# Altium schematic sheet CUBE_WIRELESS.SchDoc
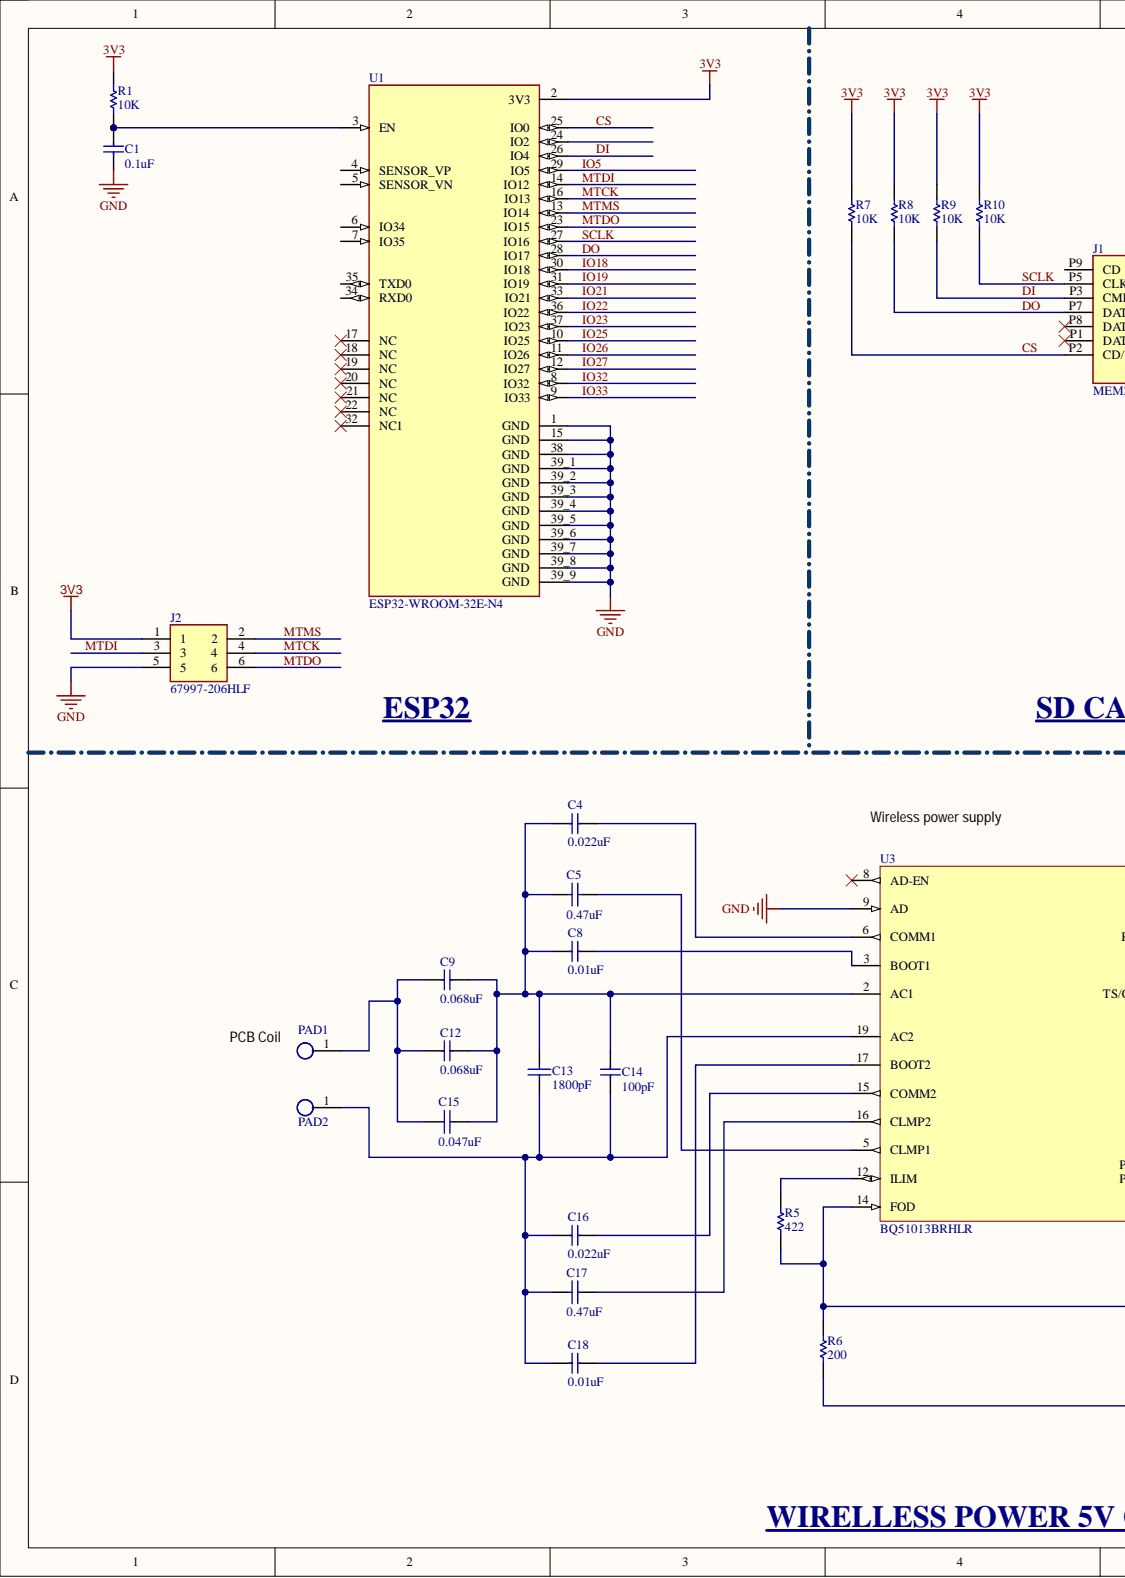
[diagram p0: CUBE_WIRELESS.SchDoc, left]
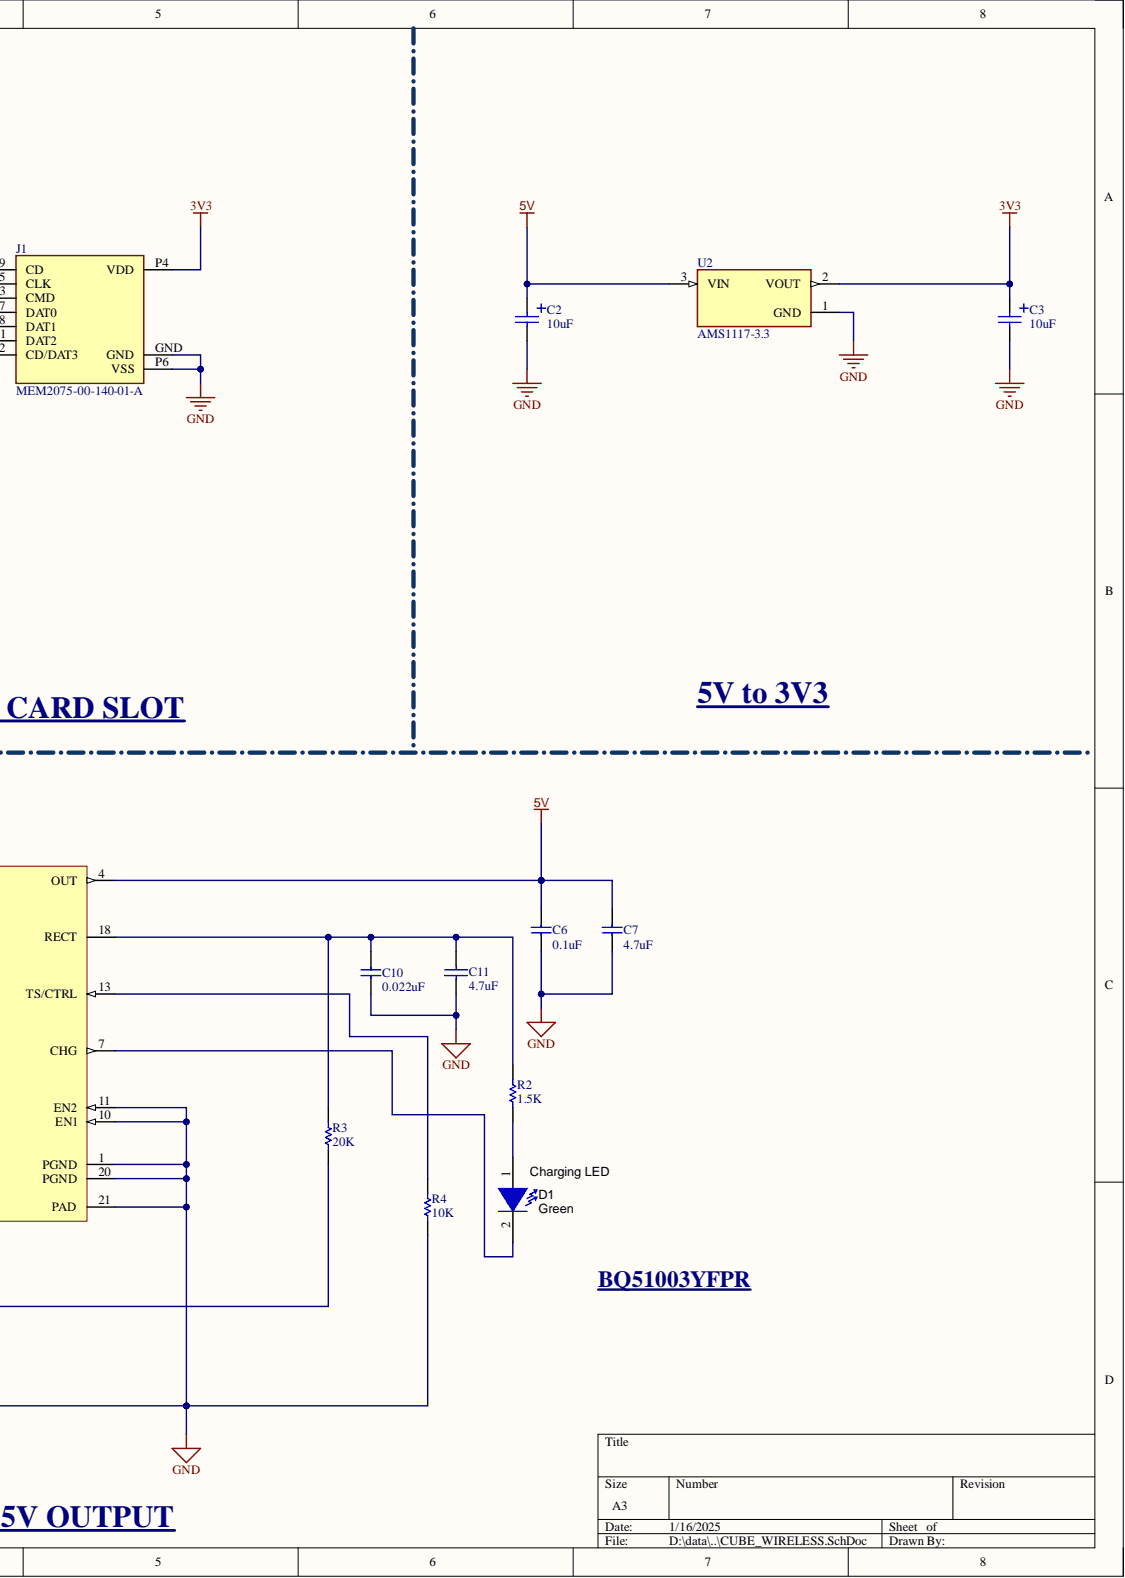
[diagram p1: CUBE_WIRELESS.SchDoc, right]

## Parts
C1  0.1uF  [CAPC1005X04L]
C2  10uF  [C0805+]
C3  10uF  [C0805+]
C4  0.022uF  [CAPC1005X04L]
C5  0.47uF  [C0603]
C6  0.1uF  [CAPC1005X04L]
C7  4.7uF  [CAPC1005X04L]
C8  0.01uF  [CAPC1005X04L]
C9  0.068uF  [CAPC1005X04L]
C10  0.022uF  [CAPC1005X04L]
C11  4.7uF  [C0603]
C12  0.068uF  [CAPC1005X04L]
C13  1800pF  [C0603]
C14  100pF  [CAPC1005X04L]
C15  0.047uF  [C0603]
C16  0.022uF  [CAPC1005X04L]
C17  0.47uF  [C0603]
C18  0.01uF  [CAPC1005X04L]
D1  value Green  [LED_LTST-C190]  (hidden comment: LTST-C190GKT)
J1  MEM2075-00-140-01-A  [MEM20750014001A]
J2  67997-206HLF  [67997-206HLF]
PAD1  PAD  [test_point]
PAD2  PAD  [test_point]
R1  10K  [R0402]
R2  1.5K  [R0402]
R3  20K  [R0402]
R4  10K  [R0402]
R5  422  [R0402]
R6  200  [R0402]
R7  10K  [R0402]
R8  10K  [R0402]
R9  10K  [R0402]
R10  10K  [R0402]
U1  ESP32-WROOM-32E-N4  [XCVR_ESP32-WROOM-32E_(16MB)]
U2  AMS1117-3.3  [SOT229P700X180-4N]
U3  BQ51013BRHLR  [RHL0020A]

## Nets
3V3: C3.1 J1.P4(VDD) J2.1 R1.2 R7.2 R8.2 R9.2 R10.2 U1.2(3V3) U2.2
5V: C2.1 C6.2 C7.2 U2.3 U3.4(OUT)
GND: C1.1 C2.2 C3.2 C6.1 C7.1 C10.1 C11.1 J1.GND J1.P6(VSS) J2.5 R4.1 R6.1 U1.1(GND) U1.15(GND) U1.38(GND) U1.39_1(GND) U1.39_2(GND) U1.39_3(GND) U1.39_4(GND) U1.39_5(GND) U1.39_6(GND) U1.39_7(GND) U1.39_8(GND) U1.39_9(GND) U2.1 U3.1(PGND) U3.9(AD) U3.10(EN1) U3.11(EN2) U3.20(PGND) U3.21(PAD)
CS: J1.P2(CD/DAT3) R7.1 U1.25(IO0)
DI: J1.P3(CMD) R9.1 U1.26(IO4)
DO: J1.P7(DAT0) R8.1 U1.28(IO17)
IO5: U1.29(IO5)
IO18: U1.30(IO18)
IO19: U1.31(IO19)
IO21: U1.33(IO21)
IO22: U1.36(IO22)
IO23: U1.37(IO23)
IO25: U1.10(IO25)
IO26: U1.11(IO26)
IO27: U1.12(IO27)
IO32: U1.8(IO32)
IO33: U1.9(IO33)
MTCK: J2.4 U1.16(IO13)
MTDI: J2.3 U1.14(IO12)
MTDO: J2.6 U1.23(IO15)
MTMS: J2.2 U1.13(IO14)
SCLK: J1.P5(CLK) R10.1 U1.27(IO16)
NetC1_2: C1.2 R1.1 U1.3(EN)
NetC4_1: C4.1 C5.1 C8.1 C9.2 C12.2 C13.2 C14.2 C15.2 U3.2(AC1)
NetC4_2: C4.2 U3.6(COMM1)
NetC5_2: C5.2 U3.5(CLMP1)
NetC8_2: C8.2 U3.3(BOOT1)
NetC9_1: C9.1 C12.1 C15.1 PAD1.1
NetC10_2: C10.2 C11.2 R2.2 R3.2 U3.18(RECT)
NetC13_1: C13.1 C14.1 C16.1 C17.1 C18.1 PAD2.1 U3.19(AC2)
NetC16_2: C16.2 U3.15(COMM2)
NetC17_2: C17.2 U3.16(CLMP2)
NetC18_2: C18.2 U3.17(BOOT2)
NetD1_1: D1.1 R2.1
NetD1_2: D1.2 U3.7(CHG)
NetR3_1: R3.1 R5.1 R6.2 U3.14(FOD)
NetR4_2: R4.2 U3.13(TS/CTRL)
NetR5_2: R5.2 U3.12(ILIM)

Not connected: J1 P1(DAT2) P8(DAT1) P9(CD); U1 4(SENSOR_VP) 5(SENSOR_VN) 6(IO34) 7(IO35) 17(NC) 18(NC) 19(NC) 20(NC) 21(NC) 22(NC) 24(IO2) 32(NC1) 34(RXD0) 35(TXD0); U3 8(AD-EN)

## Library data of the parts
C1 C4 C6-C10 C12 C14 C16 C18 = C0402: Capacitor, X5R, ±5%, 6.3V; Manufacturer: N.A.; Part Number: C0402-1µ0; Part number IPS: 00000007786, 00000003457; Artikkelnr Noca: 20039235; Pad Count: 2; Value: 1.0µF; Component Class: Capacitors; Component Kind: Standard; ComponentLink1Description: Datasheet; ComponentLink1URL: N.A.; ComponentLink2Description: Supplier link; ComponentLink2URL: N.A.; Footprint Doc: 0402; HelpURL: N.A.; LatestRevisionDate: 2016-08-22; Manufacturer Part Number: N.A.; Note: 6.3V; PartId: 100200190; Published: 2009-05-08; Supplier 1: Digi-Key; Supplier 2: N.A.; Supplier Part Number 1: 1276-1444-1-ND; Supplier Part Number 2: N.A.
  C1: Library Name: my_library.SchLib
  C4 C6-C10 C12 C14 C16 C18: Library Name: motherboard_musicsuit.SchLib
C2 C3 = tantalum_capacitor_0805: Component Kind: Standard; Library Name: my_library.SchLib
C5 C11 C13 C15 C17 = C0603: Capacitor; Component Kind: Standard; Library Name: motherboard_musicsuit.SchLib
D1 = LTST-C190GKT: LED, Green, SMD; Manufacturer: Lite-On; Io_A: 0.02; Power_TotalModule: 0.1; U/M: EA; Value1: 0.1W; Value2: 2.1V; Vf_V: 2.1; Color: green; Component Kind: Standard; ComponentLink1Description: Datasheet link; ComponentLink1URL: https://sps06.itg.ti.com/sites/KMS/crossdivteams/altium/docs/ComponentDatasheets/LTST-C190GKT.pdf; CompType: LED; LatestRevisionDate: 2015-07-30; Library Name: my_library.SchLib; MountType: SMT; PackageReference: 1.6x0.8x0.8mm; PartNumber: LTST-C190GKT; Published: 2008-07-11; RoHS: Y; Signal Integrity: Diode; Supplier 1: Digi-Key; Supplier 2: Mouser; Supplier Part Number 1: 160-1183-1-ND; Supplier Part Number 2: 859-LTST-C190GKT; SymbolVersion: 2008-12-08; Type: ?; Publisher: Texas Instruments
J1 = MEM2075-00-140-01-A: Connector; Manufacturer_Name: GCT (GLOBAL CONNECTOR TECHNOLOGY); Component Kind: Standard; Datasheet Link: http://www.farnell.com/cad/2606866.pdf; Height: 1.45mm; Library Name: my_library.SchLib; Manufacturer_Part_Number: MEM2075-00-140-01-A; Mouser Part Number: 640-MEM20750014001A; Mouser Price/Stock: https://www.mouser.co.uk/ProductDetail/GCT/MEM2075-00-140-01-A?qs=KUoIvG%2F9IlZvfWpeERlq3Q%3D%3D
J2 = 67997-206HLF: Connector Header Through Hole 6 position 0.100 _2.54mm_; MF: Amphenol ICC; Component Kind: Standard; Digi-Key_Part_Number: 609-3234-ND; Digi-Key_Purchase_URL: https://www.digikey.com/product-detail/en/amphenol-icc-fci/67997-206HLF/609-3234-ND/1878491?utm_source=snapeda&utm_medium=aggregator&utm_campaign=symbol; MP: 67997-206HLF; Package: None; SnapEDA_Link: https://www.snapeda.com/parts/67997-206HLF/Amphenol%20FCI/view-part/103429/?ref=snap; Library Name: motherboard_musicsuit.SchLib
R1-R10 = R0402: Resistor; Component Kind: Standard
  R1 R7-R10: Library Name: my_library.SchLib
  R2-R6: Library Name: motherboard_musicsuit.SchLib
U1 = ESP32-WROOM-32E-N4: Bluetooth, WiFi 802.11b/g/n, Bluetooth v4.2 +EDR, Class 1, 2 and 3 Transceiver Module 2.4GHz ~ 2.5GHz Integrated, Trace Surface Mount; MF: Espressif Systems; MP: ESP32-WROOM-32E (16MB); Availability: Not in stock; Check_prices: https://www.snapeda.com/parts/ESP32-WROOM-32E%20(16MB)/Espressif+Systems/view-part/?ref=eda; Component Kind: Standard; Library Name: my_library.SchLib; Package: None; Price: None; SnapEDA_Link: https://www.snapeda.com/parts/ESP32-WROOM-32E%20(16MB)/Espressif+Systems/view-part/?ref=snap
U2 = AMS1117-3.3: Sot223/Pkg 1-Amp 3.3-Volt Low Drop Out Voltage Regulatator; MF: Advanced Monolithic Systems; Availability: Not in stock; Check_prices: https://www.snapeda.com/parts/AMS1117-3.3/Advanced+Monolithic+Systems/view-part/?ref=eda; Component Kind: Standard; Library Name: my_library.SchLib; MP: AMS1117-3.3; Package: SOT-223 Seeed Technology; Price: None; SnapEDA_Link: https://www.snapeda.com/parts/AMS1117-3.3/Advanced+Monolithic+Systems/view-part/?ref=snap
U3 = BQ51013BRHLR: WPC 1.1 Compatible Fully integrated Wireless power Receiver IC 20-VQFN 0 to 125; MF: Texas Instruments; Arrow_Electronics-Purchase-URL: https://snapeda.com/shop?store=Arrow+Electronics&id=327516; Component Kind: Standard; Digi-Key_Part_Number: 296-38885-1-ND; DigiKey-Purchase-URL: https://snapeda.com/shop?store=DigiKey&id=327516; Library Name: my_library.SchLib; Mouser-Purchase-URL: https://snapeda.com/shop?store=Mouser&id=327516; MP: BQ51013BRHLR; Package: VQFN-20 Texas Instruments; SnapEDA_Link: https://www.snapeda.com/parts/BQ51013BRHLR/Texas%20Instruments/view-part/327516/?ref=snap; Texas_Instruments-Purchase-URL: https://snapeda.com/shop?store=Texas+Instruments&id=327516
PAD1 PAD2 = PAD: Component Kind: Standard; Library Name: my_library.SchLib
